# Altium schematic sheet Power.SchDoc(Power)
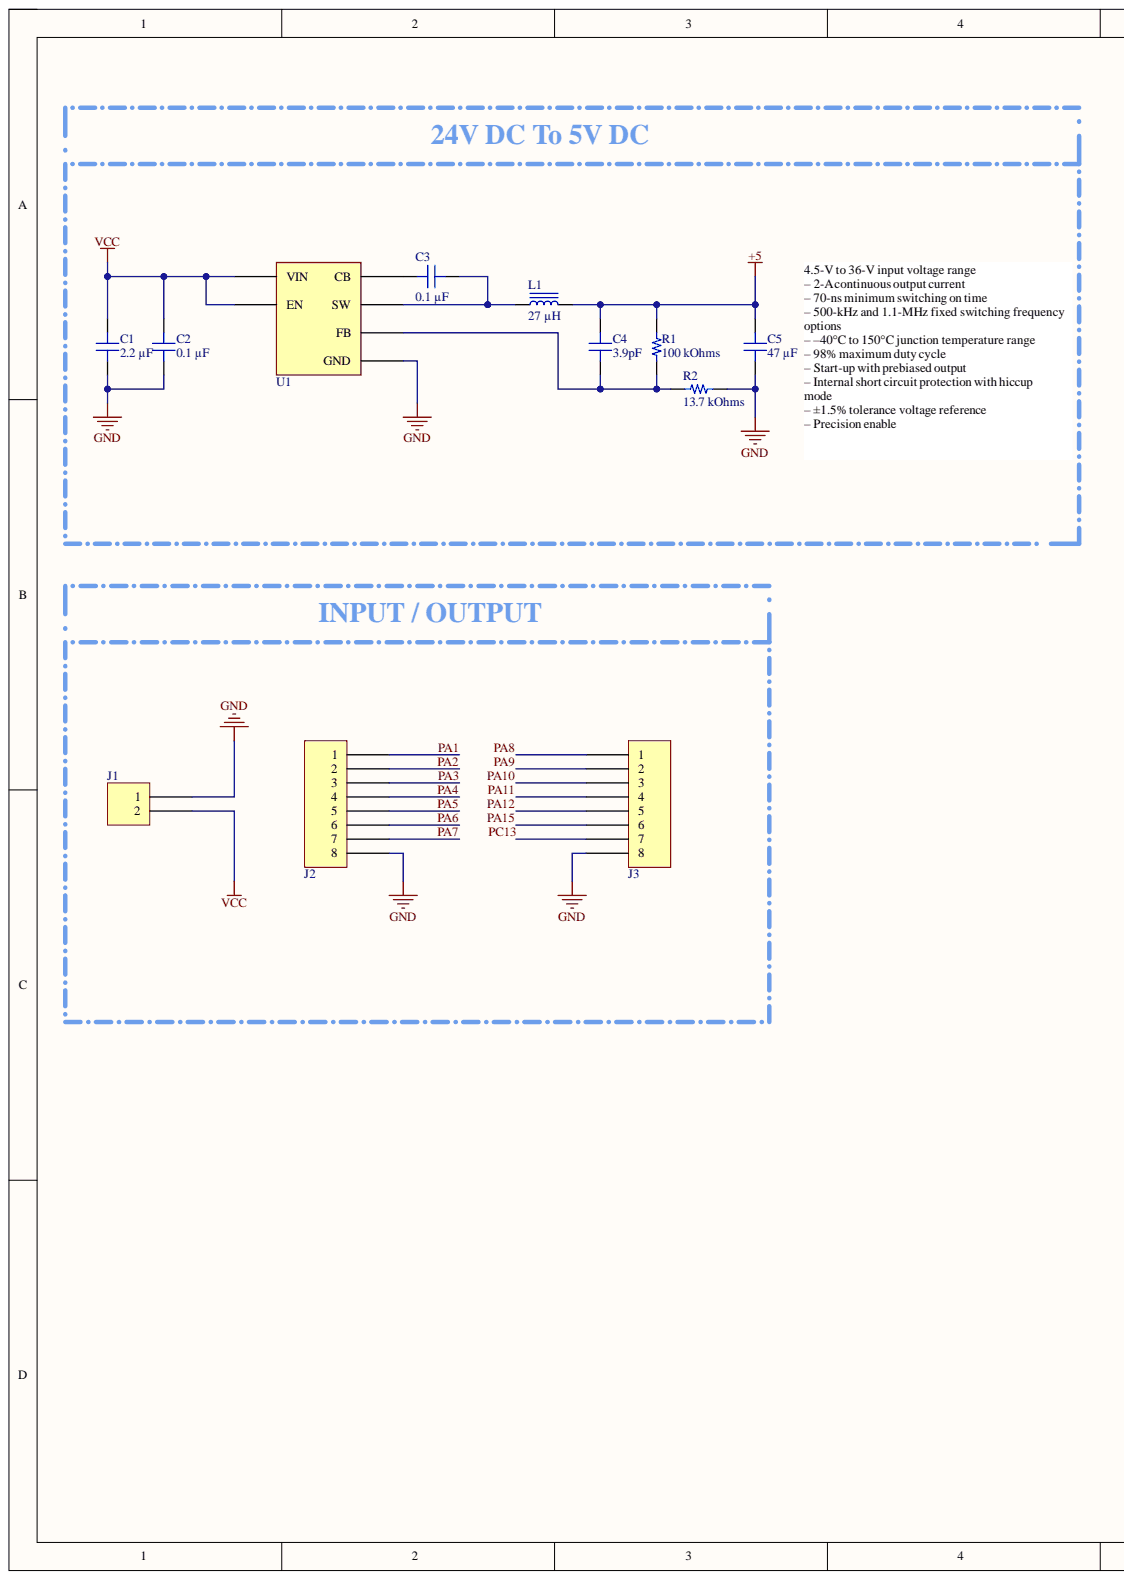
[diagram p0: Power.SchDoc, left]
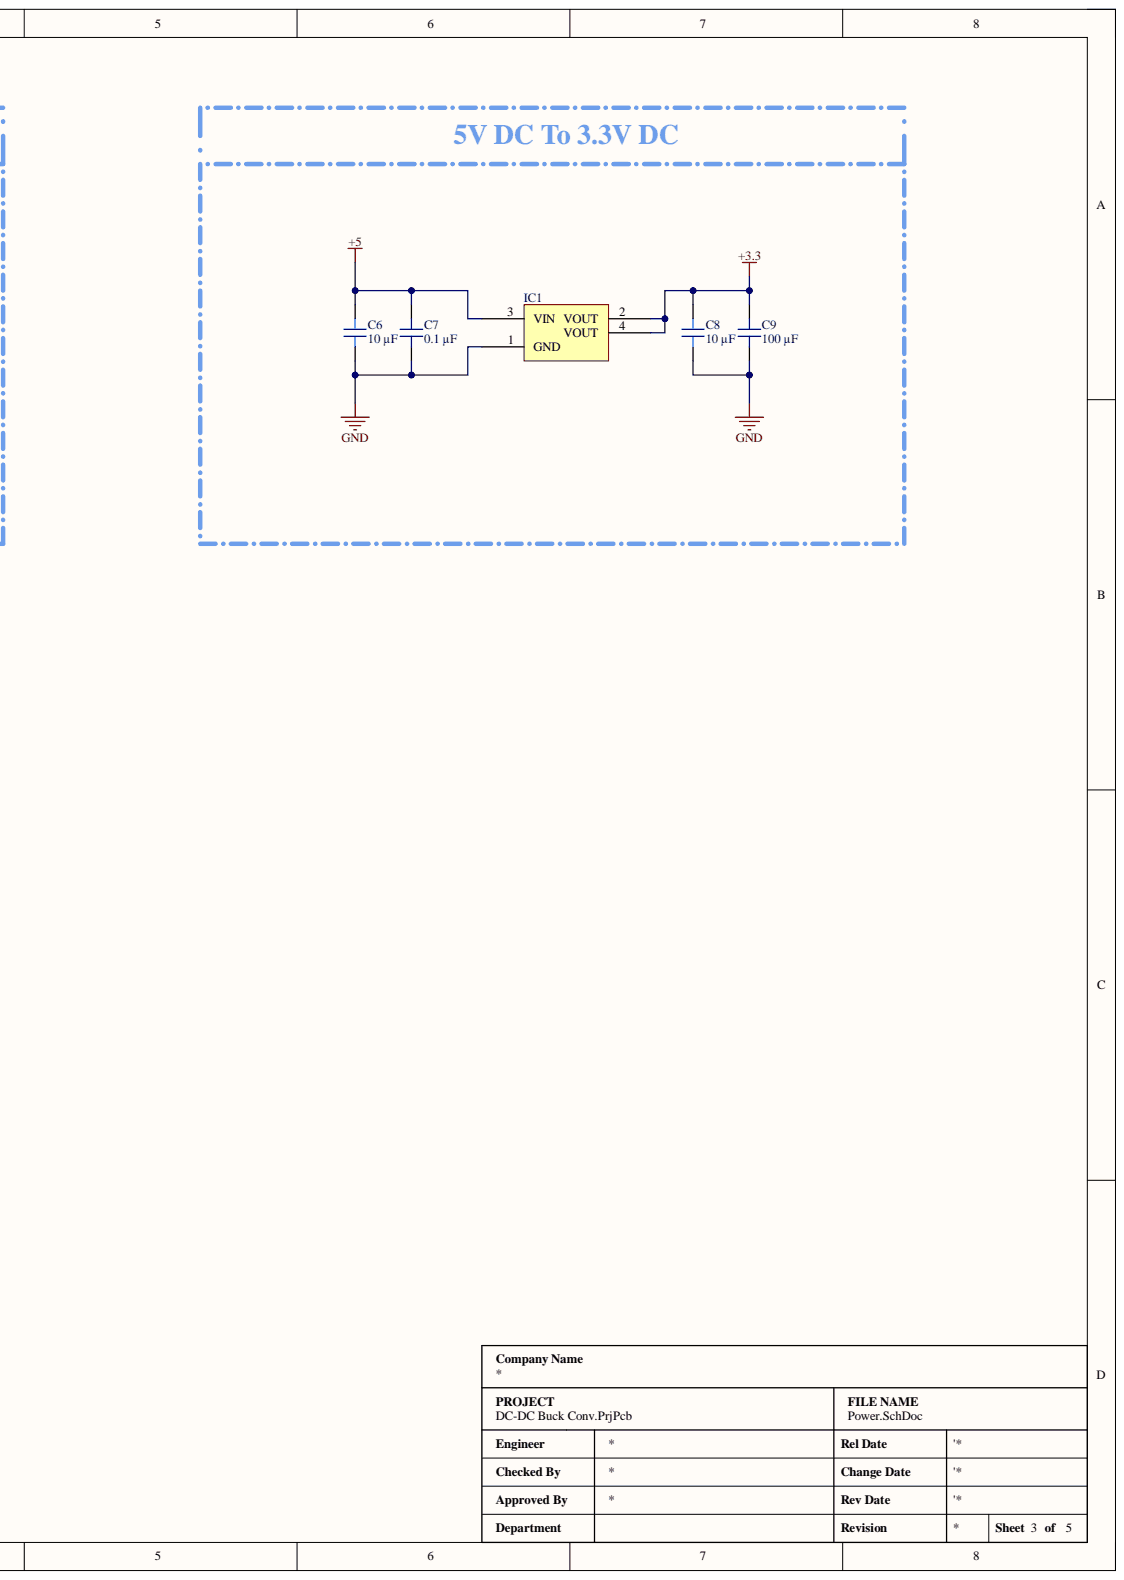
[diagram p1: Power.SchDoc, right]

## Parts
C1  2.2 µF  [CAP 1206_3216 - 0.8MM]
C2  0.1 µF  [CAP 0603_1608]
C3  0.1 µF  [CAP 0402_1005]
C4  3.9pF  [CAP 0402_1005]
C5  47 µF  [MURATA - GRM32E]
C6  10 µF  [MURATA GRM21B 0805_2012]
C7  0.1 µF  [CAP 0805_2012]
C8  10 µF  [MURATA GRM21B 0805_2012]
C9  100 µF  [MURATA GRM21B 0805_2012]
IC1  LD1117AS33TR  [ST SOT-223]  (value not drawn)
J1  282837-2  [TE 282837-2]  (value not drawn)
J2  SSW-108-03-T-S  [SAMTEC SSW-108-03-T-S]  (value not drawn)
J3  SSW-108-03-T-S  [SAMTEC SSW-108-03-T-S]  (value not drawn)
L1  27 µH  [BOURNS SRR6028]
R1  100 kOhms  [YAGEO RES 0402_1005]
R2  13.7 kOhms  [YAGEO RES 0402_1005]
U1  IC REG BUCK ADJ 2A SOT23  [SOT-23-6 Thin]  (value not drawn)

## Nets
+3.3: C8.2 C9.2 IC1.2(VOUT) IC1.4(VOUT)
+5: C4.1 C5.2 C6.2 C7.2 IC1.3(VIN) L1.2 R1.2
GND: C1.1 C2.1 C5.1 C6.1 C7.1 C8.1 C9.1 IC1.1(GND) J1.1 J2.8 J3.8 R2.2 U1.1(GND)
VCC: C1.2 C2.2 J1.2 U1.3(VIN) U1.5(EN)
PA1: J2.1
PA2: J2.2
PA3: J2.3
PA4: J2.4
PA5: J2.5
PA6: J2.6
PA7: J2.7
PA8: J3.1
PA9: J3.2
PA10: J3.3
PA11: J3.4
PA12: J3.5
PA15: J3.6
PC13: J3.7
NetC3_1: C3.1 L1.1 U1.2(SW)
NetC3_2: C3.2 U1.6(CB)
NetC4_2: C4.2 R1.1 R2.1 U1.4(FB)

## Library data of the parts
C1 = CL31B225KBHVPNE: CAP CER 2.2UF 50V X7R 1206; manufacturer: Samsung Electro-Mechanics; Database Table Name: Capacitors - Ceramic - 1206; Tolerance: ±10%; Applications: Automotive; Capacitance: 2.2 µF; Component Kind: Standard; componentlink1description: Datasheet; componentlink1url: http://www.samsungsem.com/kr/support/product-search/mlcc/CL31B225KBHVPNE.jsp; componentlink2description: DigiKey Link; componentlink2url: https://www.digikey.com/en/products/detail/samsung-electro-mechanics/CL31B225KBHVPNE/5961219; Device Package: 1206 (3216 Metric); lastupdated: 4.06.2024 19:10:40; Library Name: Celestial Altium Library - altium_library.DbLib; Lifecycle Status: Active; Minimum Order: 1; Mounting Type: Surface Mount, MLCC; Operating Temperature: -55°C ~ 125°C; Package / Case: 1206 (3216 Metric); packaging: TapeAndReel; Part Number: CL31B225KBHVPNE; Part Status: Active; partid: 20106; price: 0.05; Ratings: AEC-Q200; series: CL; Size / Dimension: 0.126" L x 0.063" W (3.20mm x 1.60mm); Supplier 1: DigiKey; Supplier Part Number 1: 1276-6735-1-ND; Temperature Coefficient: X7R; Thickness (Max): 0.071" (1.80mm); value: 2.2 µF; Voltage - Rated: 50V
C2 = C0603X104K5RAC3316: CAP CER 0603 0.1UF 50V X7R 10%; manufacturer: KEMET; Database Table Name: Capacitors - Ceramic - 0603; Applications: Automotive, Boardflex Sensitive; Capacitance: 0.1 µF; Component Kind: Standard; componentlink1description: Datasheet; componentlink1url: https://api.kemet.com/component-edge/download/datasheet/C0603X104K5RAC3316.pdf; componentlink2description: DigiKey Link; componentlink2url: https://www.digikey.com/en/products/detail/kemet/C0603X104K5RAC3316/10315788; Device Package: 0603 (1608 Metric); Features: Soft Termination; lastupdated: 9.02.2024 20:10:19; Library Name: Celestial Altium Library - altium_library.DbLib; Lifecycle Status: Active; Minimum Order: 1; Mounting Type: Surface Mount, MLCC; Operating Temperature: -55°C ~ 125°C; Package / Case: 0603 (1608 Metric); packaging: TapeAndReel; Part Number: C0603X104K5RAC3316; Part Status: Active; partid: 11116; price: 0.02; Ratings: AEC-Q200; series: SMD Auto X7R VW80808; Size / Dimension: 0.063" L x 0.031" W (1.60mm x 0.80mm); Supplier 1: DigiKey; Supplier Part Number 1: 399-C0603X104K5RAC3316CT-ND; Temperature Coefficient: X7R; Thickness (Max): 0.037" (0.95mm); Tolerance: ±10%; value: 0.1 µF; Voltage - Rated: 50V
C3 = C0402C104K4RECAUTO: CAP CER 0402 0.1UF 16V X7R 10%; manufacturer: KEMET; Database Table Name: Capacitors - Ceramic - 0402; Applications: Automotive, ESD Protection; Capacitance: 0.1 µF; Component Kind: Standard; componentlink1description: Datasheet; componentlink1url: https://api.kemet.com/component-edge/download/datasheet/C0402C104K4RECAUTO.pdf; componentlink2description: DigiKey Link; componentlink2url: https://www.digikey.com/en/products/detail/kemet/C0402C104K4RECAUTO/8563695; Device Package: 0402 (1005 Metric); lastupdated: 9.02.2024 20:04:38; Library Name: Celestial Altium Library - altium_library.DbLib; Lifecycle Status: Active; Minimum Order: 1; Mounting Type: Surface Mount, MLCC; Operating Temperature: -55°C ~ 125°C; Package / Case: 0402 (1005 Metric); packaging: TapeAndReel; Part Number: C0402C104K4RECAUTO; Part Status: Active; partid: 1731; price: 0.01; Ratings: AEC-Q200; series: ESD SMD Auto X7R; Size / Dimension: 0.039" L x 0.020" W (1.00mm x 0.50mm); Supplier 1: DigiKey; Supplier Part Number 1: 399-17167-1-ND; Temperature Coefficient: X7R; Thickness (Max): 0.022" (0.55mm); Tolerance: ±10%; value: 0.1 µF; Voltage - Rated: 16V
C4 = 04023U3R9BAT2A: CAP CER 3.9PF 25V NP0 0402; manufacturer: AVX Corporation; Database Table Name: Capacitors - Ceramic - 0402; Temperature Coefficient: C0G, NP0; Tolerance: ±0.1pF; Applications: RF, Microwave, High Frequency; Capacitance: 3.9pF; Component Kind: Standard; componentlink1description: Datasheet; componentlink1url: http://datasheets.avx.com/U-Series.pdf; componentlink2description: DigiKey Link; componentlink2url: http://digikey.com/product-detail/en/avx-corporation/04023U3R9BAT2A/478-11759-1-ND/8573395; Device Package: 0402 (1005 Metric); Features: Ultra Low ESR; lastupdated: 8.02.2022 12:26:42; Library Name: Celestial Altium Library - altium_library.DbLib; Lifecycle Status: Active; Minimum Order: 1; Mounting Type: Surface Mount, MLCC; Operating Temperature: -55°C ~ 125°C; Package / Case: 0402 (1005 Metric); packaging: TapeAndReel; Part Number: 04023U3R9BAT2A; Part Status: Active; partid: 11132; price: 0.03; series: U; Size / Dimension: 0.039" L x 0.020" W (1.00mm x 0.50mm); Supplier 1: DigiKey; Supplier Part Number 1: 478-11759-1-ND; Thickness (Max): 0.022" (0.55mm); value: 3.9pF; Voltage - Rated: 25V
C5 = GRM32EC81C476KE15L: CAP CER 47UF 16V X6S 1210; manufacturer: Murata Electronics; Database Table Name: Capacitors - Ceramic - 1210; Tolerance: ±10%; Applications: General Purpose; Capacitance: 47 µF; Component Kind: Standard; componentlink1description: Datasheet; componentlink1url: https://search.murata.co.jp/Ceramy/image/img/A01X/G101/ENG/GRM32EC81C476KE15-01.pdf; componentlink2description: DigiKey Link; componentlink2url: https://www.digikey.com/en/products/detail/murata-electronics/GRM32EC81C476KE15L/5027615; Device Package: 1210 (3225 Metric); lastupdated: 27.08.2024 14:53:22; Library Name: Celestial Altium Library - altium_library.DbLib; Lifecycle Status: Active; Minimum Order: 1; Mounting Type: Surface Mount, MLCC; Operating Temperature: -55°C ~ 105°C; Package / Case: 1210 (3225 Metric); packaging: TapeAndReel; Part Number: GRM32EC81C476KE15L; Part Status: Active; partid: 23627; price: 0.12; series: GRM; Size / Dimension: 0.126" L x 0.098" W (3.20mm x 2.50mm); Supplier 1: DigiKey; Supplier Part Number 1: 490-10531-1-ND; Temperature Coefficient: X6S; Thickness (Max): 0.106" (2.70mm); Value: 47 µF; Voltage - Rated: 16V
C6 C8 = GRM21BR61H106KE43L: CAP CER 10UF 50V X5R 0805; manufacturer: Murata Electronics; Database Table Name: Capacitors - Ceramic - 0805; Tolerance: ±10%; Applications: Mobile Devices Only; Capacitance: 10 µF; Component Kind: Standard; componentlink1description: Datasheet; componentlink1url: https://search.murata.co.jp/Ceramy/image/img/A01X/G101/ENG/GRM21BR61H106KE43-01A.pdf; componentlink2description: DigiKey Link; componentlink2url: https://www.digikey.com/en/products/detail/murata-electronics/GRM21BR61H106KE43L/10326316; Device Package: 0805 (2012 Metric); lastupdated: 27.08.2024 09:29:56; Library Name: Celestial Altium Library - altium_library.DbLib; Lifecycle Status: Active; Minimum Order: 1; Mounting Type: Surface Mount, MLCC; Operating Temperature: -55°C ~ 85°C; Package / Case: 0805 (2012 Metric); packaging: TapeAndReel; Part Number: GRM21BR61H106KE43L; Part Status: Active; partid: 39284; price: 0.05; series: GRM; Size / Dimension: 0.079" L x 0.049" W (2.00mm x 1.25mm); Supplier 1: DigiKey; Supplier Part Number 1: 490-18663-1-ND; Temperature Coefficient: X5R; Thickness (Max): 0.057" (1.45mm); value: 10 µF; Voltage - Rated: 50V
C7 = C0805C104J1RECAUTO: CAP CER 0805 0.1UF 100V X7R 5%; manufacturer: KEMET; Database Table Name: Capacitors - Ceramic - 0805; Applications: Automotive, ESD Protection; Capacitance: 0.1 µF; Component Kind: Standard; componentlink1description: Datasheet; componentlink1url: https://api.kemet.com/component-edge/download/datasheet/C0805C104J1RECAUTO.pdf; componentlink2description: DigiKey Link; componentlink2url: https://www.digikey.com/en/products/detail/kemet/C0805C104J1RECAUTO/8646637; Device Package: 0805 (2012 Metric); lastupdated: 9.02.2024 20:15:06; Library Name: Celestial Altium Library - altium_library.DbLib; Lifecycle Status: Active; Minimum Order: 1; Mounting Type: Surface Mount, MLCC; Operating Temperature: -55°C ~ 125°C; Package / Case: 0805 (2012 Metric); packaging: TapeAndReel; Part Number: C0805C104J1RECAUTO; Part Status: Active; partid: 31979; price: 0.05; Ratings: AEC-Q200; series: ESD SMD Auto X7R; Size / Dimension: 0.079" L x 0.049" W (2.00mm x 1.25mm); Supplier 1: DigiKey; Supplier Part Number 1: 399-C0805C104J1RECAUTOCT-ND; Temperature Coefficient: X7R; Thickness (Max): 0.043" (1.10mm); Tolerance: ±5%; value: 0.1 µF; Voltage - Rated: 100V
C9 = GRM21BR60J107ME15K: CAP CER 100UF 6.3V X5R 0805; manufacturer: Murata Electronics; Database Table Name: Capacitors - Ceramic - 0805; Tolerance: ±20%; Applications: General Purpose; Capacitance: 100 µF; Component Kind: Standard; componentlink1description: Datasheet; componentlink1url: https://search.murata.co.jp/Ceramy/image/img/A01X/G101/ENG/GRM21BR60J107ME15-01A.pdf; componentlink2description: DigiKey Link; componentlink2url: https://www.digikey.com/en/products/detail/murata-electronics/GRM21BR60J107ME15K/9887675; Device Package: 0805 (2012 Metric); lastupdated: 27.08.2024 09:29:54; Library Name: Celestial Altium Library - altium_library.DbLib; Lifecycle Status: Active; Minimum Order: 1; Mounting Type: Surface Mount, MLCC; Operating Temperature: -55°C ~ 85°C; Package / Case: 0805 (2012 Metric); packaging: TapeAndReel; Part Number: GRM21BR60J107ME15K; Part Status: Active; partid: 39832; price: 0.39; series: GRM; Size / Dimension: 0.079" L x 0.049" W (2.00mm x 1.25mm); Supplier 1: DigiKey; Supplier Part Number 1: 490-GRM21BR60J107ME15KCT-ND; Temperature Coefficient: X5R; Thickness (Max): 0.057" (1.45mm); value: 100 µF; Voltage - Rated: 6.3V
IC1 = LD1117AS33TR: IC REG LINEAR 3.3V 1A SOT223; Manufacturer: STMicroelectronics; Current - Quiescent (Iq): 10 mA; Database Table Name: Voltage Regulators - Linear; Number of Regulators: 1; Output Configuration: Positive; Output Type: Fixed; Protection Features: Over Current, Over Temperature; PSRR: 75dB (120Hz); Voltage - Input (Max): 15V; Voltage Dropout (Max): 1.3V @ 1A; Component Kind: Standard; ComponentLink1Description: Datasheet; ComponentLink1URL: http://www.st.com/content/ccc/resource/technical/document/datasheet/a5/c3/3f/c9/2b/15/40/49/CD00002116.pdf/files/CD00002116.pdf/jcr:content/translations/en.CD00002116.pdf; ComponentLink2Description: DigiKey Link; ComponentLink2URL: https://www.digikey.com/en/products/detail/stmicroelectronics/LD1117AS33TR/585752; Current - Output: 1A; LastUpdated: 3.06.2024 20:14:21; Library Name: Celestial Altium Library - altium_library.DbLib; Lifecycle Status: Active; Minimum Order: 1; Mounting Type: Surface Mount; Operating Temperature: 0°C ~ 125°C; Package / Case: TO-261-4, TO-261AA; Packaging: TapeAndReel; Part Number: LD1117AS33TR; Part Status: Active; Price: 0.32; Series: -; Supplier 1: DigiKey; Supplier Device Package: SOT-223; Supplier Part Number 1: 497-1228-1-ND; Voltage - Output (Min/Fixed): 3.3V
J1 = 282837-2: TERM BLK 2P SIDE ENT 5.08MM PCB; Manufacturer: TE Connectivity AMP Connectors; Current: 13.5 A; Database Table Name: Connectors - Terminal Blocks - Wire to Board; Mating Orientation: Horizontal with Board; Number of Levels: 1; Pitch: 0.200" (5.08mm); Positions Per Level: 2; Voltage: 300 V; Wire Gauge: 16-28 AWG; Wire Termination: Screw - Rising Cage Clamp; Color: Green; Component Kind: Standard; ComponentLink1Description: Datasheet; ComponentLink1URL: https://www.te.com/commerce/DocumentDelivery/DDEController?Action=srchrtrv&DocNm=282837&DocType=Customer+Drawing&DocLang=English; ComponentLink2Description: DigiKey Link; ComponentLink2URL: https://www.digikey.com/en/products/detail/te-connectivity-amp-connectors/282837-2/2187973; Features: Interlocking (Side); LastUpdated: 21.06.2022 15:00:23; Library Name: Celestial Altium Library - altium_library.DbLib; Lifecycle Status: Active; Minimum Order: 1; Mounting Type: Through Hole; Packaging: Tray; Part Number: 282837-2; Part Status: Active; Price: 0.31; Series: Buchanan; Supplier 1: DigiKey; Supplier Part Number 1: A113320-ND
J2 J3 = SSW-108-03-T-S: CONN RCPT 8POS 0.1 TIN PCB; Manufacturer: Samtec Inc.; Connector Type: Receptacle; Contact Length - Post: 0.394" (10.00mm); Current Rating (Amps): 5.7A per Contact; Database Table Name: Connectors - Rectangular Headers Female Sockets; Material Flammability Rating: UL94 V-0; Number of Positions: 8; Number of Positions Loaded: All; Pitch - Mating: 0.100" (2.54mm); Style: Board to Board or Cable; Component Kind: Standard; ComponentLink1Description: Datasheet; ComponentLink1URL: http://suddendocs.samtec.com/catalog_english/ssw_th.pdf; ComponentLink2Description: DigiKey Link; ComponentLink2URL: https://www.digikey.com/en/products/detail/samtec-inc/SSW-108-03-T-S/1790200; Contact Finish - Mating: Tin; Contact Finish - Post: Tin; Contact Type: Forked; Fastening Type: Push-Pull; LastUpdated: 21.06.2022 15:09:52; Library Name: Celestial Altium Library - altium_library.DbLib; Lifecycle Status: Active; Minimum Order: 1; Mounting Type: Through Hole; Number of Rows: 1; Operating Temperature: -55°C ~ 105°C; Packaging: Bulk; Part Number: SSW-108-03-T-S; Part Status: Active; Price: 0.73; Series: SSW; Supplier 1: DigiKey; Supplier Part Number 1: SAM8866-ND; Termination: Solder; Insulation Color: Black; Insulation Height: 0.335" (8.51mm)
L1 = SRR6028-270Y: FIXED IND 27UH 1.2A 130 MOHM SMD; Manufacturer: Bourns Inc.; Current - Saturation: 1A; Database Table Name: Inductors - Power; DC Resistance (DCR): 130mOhm Max; Frequency - Self Resonant: 19MHz; Material - Core: Ferrite; Q @ Freq: 9 @ 2.52MHz; Shielding: Shielded; Tolerance: ±30%; Type: Drum Core, Wirewound; Inductance Frequency - Test: 100 kHz; Component Kind: Standard; ComponentLink1Description: Datasheet; ComponentLink1URL: https://www.bourns.com/docs/Product-Datasheets/SRR6028.pdf; ComponentLink2Description: DigiKey Link; ComponentLink2URL: https://www.digikey.com/en/products/detail/bourns-inc/SRR6028-270Y/1970380; Current Rating (Amps): 1.2 A; Device Package: Nonstandard; Height - Seated (Max): 0.118" (3.00mm); LastUpdated: 3.06.2024 20:02:38; Library Name: Celestial Altium Library - altium_library.DbLib; Lifecycle Status: Active; Minimum Order: 1; Mounting Type: Surface Mount; Operating Temperature: -40°C ~ 125°C; Package / Case: Nonstandard; Packaging: TapeAndReel; Part Number: SRR6028-270Y; Part Status: Active; PartId: 53; Price: 0.31; Series: SRR6028; Size / Dimension: 0.268" L x 0.268" W (6.80mm x 6.80mm); Supplier 1: DigiKey; Supplier Part Number 1: 118-SRR6028-270YCT-ND; Value: 27 µH; Inductance: 27 µH
R1 = RC0402FR-07162KL: RES 162K OHM 1% 1/16W 0402; Manufacturer: Yageo; Database Table Name: Resistors - Surface Mount - 0402; Power (Watts): 0.063W, 1/16W; Temperature Coefficient: ±100ppm/°C; Component Kind: Standard; ComponentLink1Description: Datasheet; ComponentLink1URL: https://www.yageo.com/upload/media/product/productsearch/datasheet/rchip/PYu-RC_Group_51_RoHS_L_10.pdf; ComponentLink2Description: DigiKey Link; ComponentLink2URL: https://www.digikey.com/en/products/detail/yageo/RC0402FR-07162KL/2345133; Composition: Thick Film; Device Package: 0402 (1005 Metric); Features: Moisture Resistant; Height - Seated (Max): 0.016" (0.40mm); LastUpdated: 25.07.2024 09:18:19; Library Name: Celestial Altium Library - altium_library.DbLib; Lifecycle Status: Active; Minimum Order: 1; Number of Terminations: 2; Operating Temperature: -55°C ~ 155°C; Package / Case: 0402 (1005 Metric); Packaging: CutTape; Part Number: RC0402FR-07162KL; Part Status: Active; PartId: 2536; Price: 0.01; Resistance: 100 kOhms; Series: RC_L; Size / Dimension: 0.039" L x 0.020" W (1.00mm x 0.50mm); Supplier 1: DigiKey; Supplier Device Package: 0402; Supplier Part Number 1: YAG2370CT-ND; Tolerance: ±1%; Value: 100 kOhms
R2 = RC0402FR-0722K1L: RES 22.1K OHM 1% 1/16W 0402; Manufacturer: Yageo; Database Table Name: Resistors - Surface Mount - 0402; Power (Watts): 0.063W, 1/16W; Temperature Coefficient: ±100ppm/°C; Component Kind: Standard; ComponentLink1Description: Datasheet; ComponentLink1URL: https://www.yageo.com/upload/media/product/productsearch/datasheet/rchip/PYu-RC_Group_51_RoHS_L_10.pdf; ComponentLink2Description: DigiKey Link; ComponentLink2URL: https://www.digikey.com/en/products/detail/yageo/RC0402FR-0722K1L/726563; Composition: Thick Film; Device Package: 0402 (1005 Metric); Features: Moisture Resistant; Height - Seated (Max): 0.016" (0.40mm); LastUpdated: 25.07.2024 09:18:19; Library Name: Celestial Altium Library - altium_library.DbLib; Lifecycle Status: Active; Minimum Order: 1; Number of Terminations: 2; Operating Temperature: -55°C ~ 155°C; Package / Case: 0402 (1005 Metric); Packaging: CutTape; Part Number: RC0402FR-0722K1L; Part Status: Active; PartId: 2641; Price: 0.01; Resistance: 13.7 kOhms; Series: RC_L; Size / Dimension: 0.039" L x 0.020" W (1.00mm x 0.50mm); Supplier 1: DigiKey; Supplier Device Package: 0402; Supplier Part Number 1: 311-22.1KLRCT-ND; Tolerance: ±1%; Value: 13.7 kOhms
U1 = LMR51420XDDCR: IC REG BUCK ADJ 2A SOT23-THIN "Buck Switching Regulator IC Positive Adjustable 0.6V 1 Output 2A SOT-23-6 Thin,...; Component Kind: Standard; Library Name: DcDcBuck.IntLib
